# Altium schematic sheet Sensor Brushless Driver V1.01.SchDoc
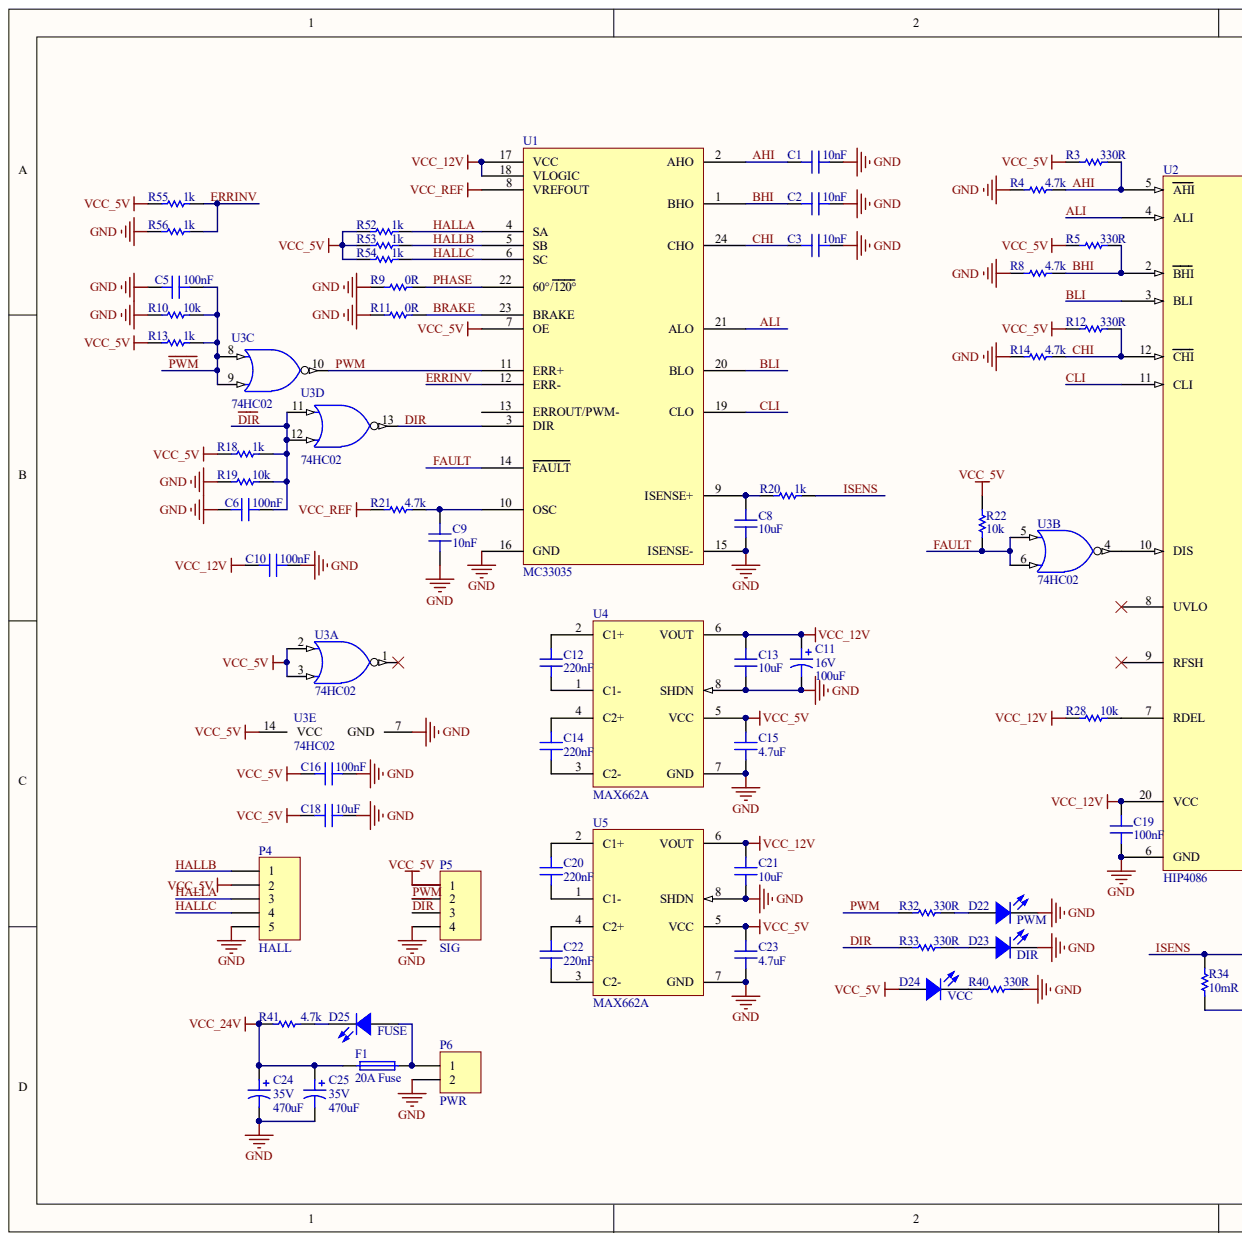
[diagram p0: Sensor Brushless Driver V1.01.SchDoc, left]
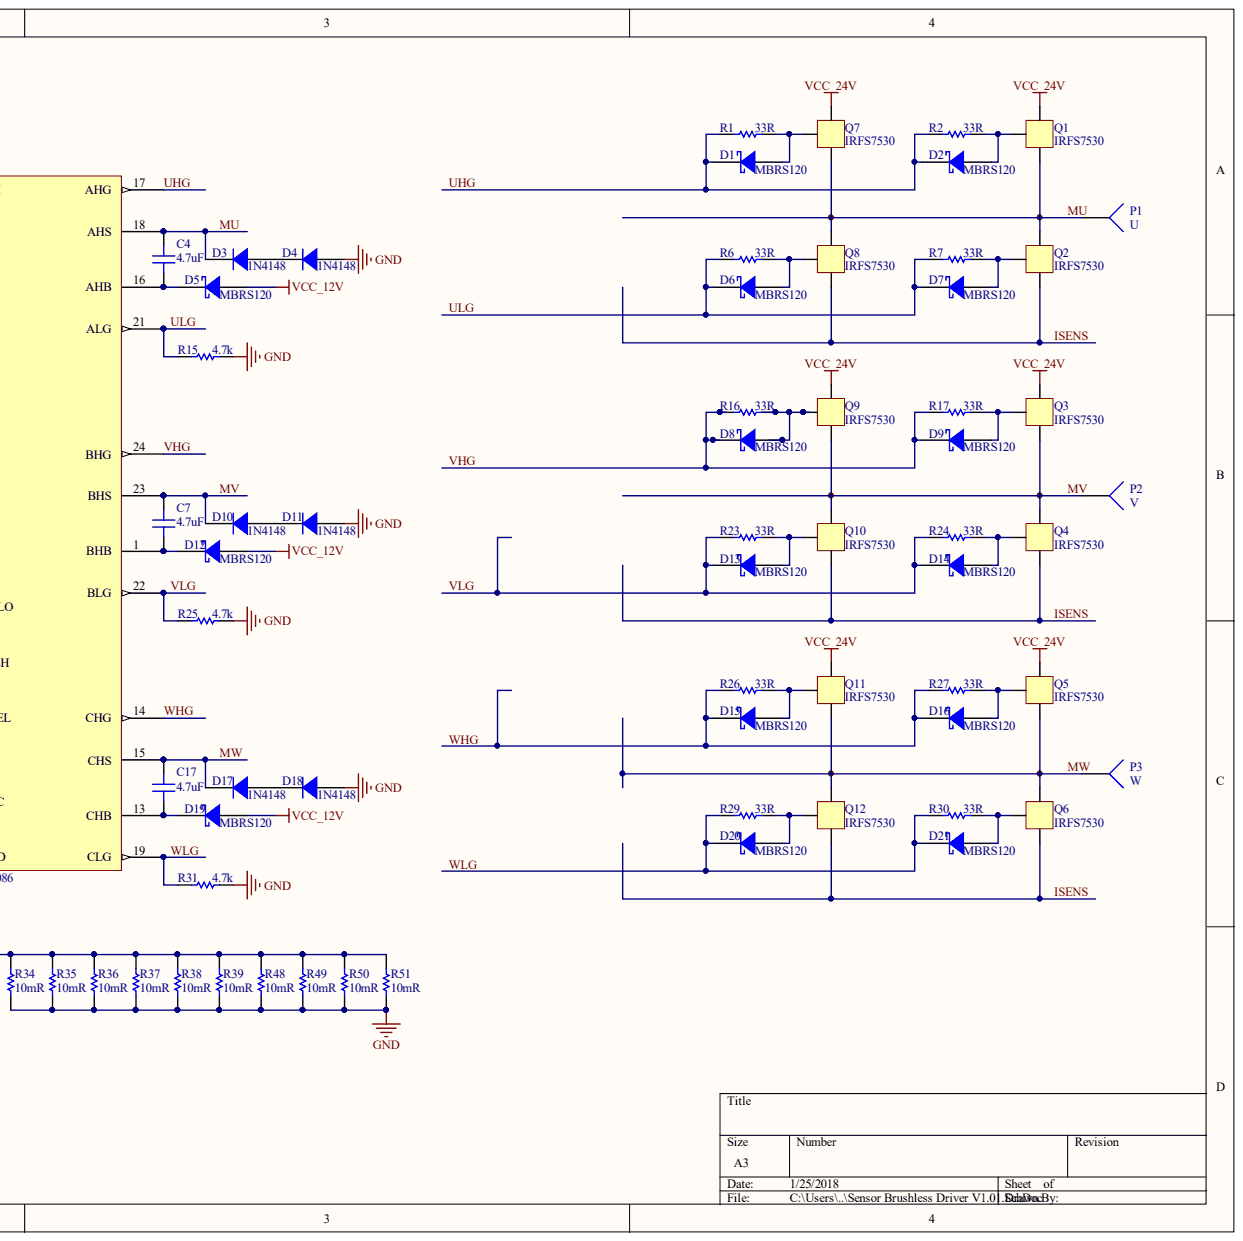
[diagram p1: Sensor Brushless Driver V1.01.SchDoc, right]

## Parts
C1  10nF  [CC2012-0805]
C2  10nF  [CC2012-0805]
C3  10nF  [CC2012-0805]
C4  4.7uF  [CC2012-0805]
C5  100nF  [CC2012-0805]
C6  100nF  [CC2012-0805]
C7  4.7uF  [CC2012-0805]
C8  10uF  [CC3216-1206]
C9  10nF  [CC2012-0805]
C10  100nF  [CC2012-0805]
C11  100uF  Voltage: 16V  [EIA7343]
C12  220nF  [CC2012-0805]
C13  10uF  [CC3216-1206]
C14  220nF  [CC2012-0805]
C15  4.7uF  [CC2012-0805]
C16  100nF  [CC2012-0805]
C17  4.7uF  [CC2012-0805]
C18  10uF  [CC3216-1206]
C19  100nF  [CC2012-0805]
C20  220nF  [CC2012-0805]
C21  10uF  [CC3216-1206]
C22  220nF  [CC2012-0805]
C23  4.7uF  [CC2012-0805]
C24  470uF  Voltage: 35V  [CAP10x12]
C25  470uF  Voltage: 35V  [CAP10x12]
D1  MBRS120  [SMA]
D2  MBRS120  [SMA]
D3  1N4148  [SOD-80]
D4  1N4148  [SOD-80]
D5  MBRS120  [SMA]
D6  MBRS120  [SMA]
D7  MBRS120  [SMA]
D8  MBRS120  [SMA]
D9  MBRS120  [SMA]
D10  1N4148  [SOD-80]
D11  1N4148  [SOD-80]
D12  MBRS120  [SMA]
D13  MBRS120  [SMA]
D14  MBRS120  [SMA]
D15  MBRS120  [SMA]
D16  MBRS120  [SMA]
D17  1N4148  [SOD-80]
D18  1N4148  [SOD-80]
D19  MBRS120  [SMA]
D20  MBRS120  [SMA]
D21  MBRS120  [SMA]
D22  PWM  [D0805]
D23  DIR  [D0805]
D24  VCC  [D0805]
D25  FUSE  [D0805]
F1  20A Fuse  [FUSE SOCKET]
P1  U  [2.5X4_WIRE_PAD]
P2  V  [2.5X4_WIRE_PAD]
P3  W  [2.5X4_WIRE_PAD]
P4  HALL  [LOCKEDHDR-5W]
P5  SIG  [LOCKEDHDR-4W]
P6  PWR  [xt60(m)]
Q1  IRFS7530  [D2k 7 pin]
Q2  IRFS7530  [D2k 7 pin]
Q3  IRFS7530  [D2k 7 pin]
Q4  IRFS7530  [D2k 7 pin]
Q5  IRFS7530  [D2k 7 pin]
Q6  IRFS7530  [D2k 7 pin]
Q7  IRFS7530  [D2k 7 pin]
Q8  IRFS7530  [D2k 7 pin]
Q9  IRFS7530  [D2k 7 pin]
Q10  IRFS7530  [D2k 7 pin]
Q11  IRFS7530  [D2k 7 pin]
Q12  IRFS7530  [D2k 7 pin]
R1  33R  [CR2012-0805]
R2  33R  [CR2012-0805]
R3  330R  [CR2012-0805]
R4  4.7k  [CR2012-0805]
R5  330R  [CR2012-0805]
R6  33R  [CR2012-0805]
R7  33R  [CR2012-0805]
R8  4.7k  [CR2012-0805]
R9  0R  [CR2012-0805]
R10  10k  [CR2012-0805]
R11  0R  [CR2012-0805]
R12  330R  [CR2012-0805]
R13  1k  [CR2012-0805]
R14  4.7k  [CR2012-0805]
R15  4.7k  [CR2012-0805]
R16  33R  [CR2012-0805]
R17  33R  [CR2012-0805]
R18  1k  [CR2012-0805]
R19  10k  [CR2012-0805]
R20  1k  [CR2012-0805]
R21  4.7k  [CR2012-0805]
R22  10k  [CR2012-0805]
R23  33R  [CR2012-0805]
R24  33R  [CR2012-0805]
R25  4.7k  [CR2012-0805]
R26  33R  [CR2012-0805]
R27  33R  [CR2012-0805]
R28  10k  [CR2012-0805]
R29  33R  [CR2012-0805]
R30  33R  [CR2012-0805]
R31  4.7k  [CR2012-0805]
R32  330R  [CR2012-0805]
R33  330R  [CR2012-0805]
R34  10mR  [CR6332-2512]
R35  10mR  [CR6332-2512]
R36  10mR  [CR6332-2512]
R37  10mR  [CR6332-2512]
R38  10mR  [CR6332-2512]
R39  10mR  [CR6332-2512]
R40  330R  [CR2012-0805]
R41  4.7k  [CR2012-0805]
R48  10mR  [CR6332-2512]
R49  10mR  [CR6332-2512]
R50  10mR  [CR6332-2512]
R51  10mR  [CR6332-2512]
R52  1k  [CR2012-0805]
R53  1k  [CR2012-0805]
R54  1k  [CR2012-0805]
R55  1k  [CR2012-0805]
R56  1k  [CR2012-0805]
U1  MC33035  [SOP-24]
U2  HIP4086  [SOP-24]
U3  74HC02  [SOP-14]  (parts A,B,C,D,E)
U4  MAX662A  [SOP-8]
U5  MAX662A  [SOP-8]

## Nets
GND: C1.1 C2.1 C3.1 C5.2 C6.2 C8.2 C9.2 C10.2 C11.2 C13.2 C15.2 C16.2 C18.2 C19.2 C21.2 C23.2 C24.2 C25.2 D4.1 D11.1 D18.1 D22.2 D23.2 P4.5 P5.4 P6.2 R4.2 R8.2 R9.2 R10.2 R11.2 R14.2 R15.1 R19.2 R25.1 R31.1 R34.1 R35.1 R36.1 R37.1 R38.1 R39.1 R40.1 R48.1 R49.1 R50.1 R51.1 R56.2 U1.15(ISENSE-) U1.16(GND) U2.6(GND) U3.7(GND) U4.7(GND) U4.8(SHDN) U5.7(GND) U5.8(SHDN)
VCC_5V: C15.1 C16.1 C18.1 C23.1 D24.1 P4.2 P5.1 R3.2 R5.2 R12.2 R13.2 R18.2 R22.2 R52.2 R53.2 R54.2 R55.2 U1.7(OE) U3.2 U3.3 U3.14(VCC) U4.5(VCC) U5.5(VCC)
VCC_12V: C10.1 C11.1 C13.1 C19.1 C21.1 D5.1 D12.1 D19.1 R28.2 U1.17(VCC) U1.18(VLOGIC) U2.20(VCC) U4.6(VOUT) U5.6(VOUT)
VCC_24V: C24.1 C25.1 F1.1 Q1.2 Q3.2 Q5.2 Q7.2 Q9.2 Q11.2 R41.1
VCC_REF: R21.1 U1.8(VREFOUT)
AHI: C1.2 R3.1 R4.1 U1.2(AHO) U2.5(AHI)
ALI: U1.21(ALO) U2.4(ALI)
BHI: C2.2 R5.1 R8.1 U1.1(BHO) U2.2(BHI)
BLI: U1.20(BLO) U2.3(BLI)
BRAKE: R11.1 U1.23(BRAKE)
CHI: C3.2 R12.1 R14.1 U1.24(CHO) U2.12(CHI)
CLI: U1.19(CLO) U2.11(CLI)
~{DIR}: C6.1 P5.3 R18.1 R19.1 U3.11 U3.12
DIR: R33.2 U1.3(DIR) U3.13
ERRINV: R55.1 R56.1 U1.12(ERR-)
FAULT: R22.1 U1.14(FAULT) U3.5 U3.6
HALLA: P4.3 R52.1 U1.4(SA)
HALLB: P4.1 R53.1 U1.5(SB)
HALLC: P4.4 R54.1 U1.6(SC)
ISENS: Q2.0 Q4.0 Q6.0 Q8.0 Q10.0 Q12.0 R20.1 R34.2 R35.2 R36.2 R37.2 R38.2 R39.2 R48.2 R49.2 R50.2 R51.2
MU: C4.2 D3.2 P1.1 Q1.0 Q2.2 Q7.0 Q8.2 U2.18(AHS)
MV: C7.2 D10.2 P2.1 Q3.0 Q4.2 Q9.0 Q10.2 U2.23(BHS)
MW: C17.2 D17.2 P3.1 Q5.0 Q6.2 Q11.0 Q12.2 U2.15(CHS)
~{PWM}: C5.1 P5.2 R10.1 R13.1 U3.8 U3.9
PHASE: R9.1 U1.22(60°/120°)
PWM: R32.2 U1.11(ERR+) U3.10
UHG: D1.2 D2.2 R1.1 R2.1 U2.17(AHG)
ULG: D6.2 D7.2 R6.1 R7.1 R15.2 U2.21(ALG)
VHG: D8.2 D9.2 R16.1 R17.1 U2.24(BHG)
VLG: D13.2 D14.2 R23.1 R24.1 R25.2 U2.22(BLG)
WHG: D15.2 D16.2 R26.1 R27.1 U2.14(CHG)
WLG: D20.2 D21.2 R29.1 R30.1 R31.2 U2.19(CLG)
NetC4_1: C4.1 D5.2 U2.16(AHB)
NetC7_1: C7.1 D12.2 U2.1(BHB)
NetC8_1: C8.1 R20.2 U1.9(ISENSE+)
NetC9_1: C9.1 R21.2 U1.10(OSC)
NetC12_1: C12.1 U4.1(C1-)
NetC12_2: C12.2 U4.2(C1+)
NetC14_1: C14.1 U4.3(C2-)
NetC14_2: C14.2 U4.4(C2+)
NetC17_1: C17.1 D19.2 U2.13(CHB)
NetC20_1: C20.1 U5.1(C1-)
NetC20_2: C20.2 U5.2(C1+)
NetC22_1: C22.1 U5.3(C2-)
NetC22_2: C22.2 U5.4(C2+)
NetD1_1: D1.1 Q7.1 R1.2
NetD2_1: D2.1 Q1.1 R2.2
NetD3_1: D3.1 D4.2
NetD6_1: D6.1 Q8.1 R6.2
NetD7_1: D7.1 Q2.1 R7.2
NetD8_1: D8.1 Q9.1 R16.2
NetD9_1: D9.1 Q3.1 R17.2
NetD10_1: D10.1 D11.2
NetD13_1: D13.1 Q10.1 R23.2
NetD14_1: D14.1 Q4.1 R24.2
NetD15_1: D15.1 Q11.1 R26.2
NetD16_1: D16.1 Q5.1 R27.2
NetD17_1: D17.1 D18.2
NetD20_1: D20.1 Q12.1 R29.2
NetD21_1: D21.1 Q6.1 R30.2
NetD22_1: D22.1 R32.1
NetD23_1: D23.1 R33.1
NetD24_2: D24.2 R40.2
NetD25_1: D25.1 F1.2 P6.1
NetD25_2: D25.2 R41.2
NetR28_1: R28.1 U2.7(RDEL)
NetU2_10: U2.10(DIS) U3.4

Not connected: U1 13(ERROUT/PWM-); U2 8(UVLO) 9(RFSH); U3 1

## Library data of the parts
C1-C10 C12-C23 = Cap Semi: Capacitor (Semiconductor SIM Model); Component Kind: Standard; LatestRevisionDate: 17-Jul-2002; LatestRevisionNote: Re-released for DXP Platform.; Library Name: Robocon_RCL.IntLib; PackageReference: C1206; Published: 8-Jun-2000; Signal Integrity: Cap Semi; Publisher: Altium Limited
C11 = Cap Pol SMD: Polarized Capacitor (Axial); Component Kind: Standard; LatestRevisionDate: 17-Jul-2002; LatestRevisionNote: Re-released for DXP Platform.; Library Name: Robocon_RCL.IntLib; Published: 8-Jun-2000; Publisher: Altium Limited
C24 C25 = Cap Pol DIP: Polarized Capacitor (Radial); Component Kind: Standard; LatestRevisionDate: 17-Jul-2002; LatestRevisionNote: Re-released for DXP Platform.; Library Name: Robocon_RCL.IntLib; Published: 8-Jun-2000; Publisher: Altium Limited
D1 D2 D5-D9 D12-D16 D19-D21 = D Schottky: Schottky Diode; Code - JEDEC: DO-214-AA; Component Kind: Standard; Library Name: Robocon_BJT.IntLib; Published: 8-Jun-2000; Revision: July-2002: Re-released for DXP Platform.; Publisher: Altium Limited
D3 D4 D10 D11 D17 D18 = D General: General Purpose Diode; Code - JEDEC: DO-204-AH; Revision 1: 11-Jul-2000: SIM Model Added.; Revision 2: 17-Jul-2002: Re-released for DXP Platform.; Component Kind: Standard; Datasheet: Latest Revision: 1997; Library Name: Robocon_BJT.IntLib; Package Reference: DO-35; Published: 8-Jun-2000; Publisher: Altium Limited
D22-D25 = LED: Typical RED GaAs LED; Component Kind: Standard; LatestRevisionDate: 08-Jul-2005; LatestRevisionNote: Mech Layer 1 removed.; Library Name: Robocon_BJT.IntLib; PackageReference: LED-1; Published: 8-Jun-2000; Publisher: Altium Limited
F1 = Fuse: Component Kind: Standard; Description: Fuse; Library Name: Robocon_RCL.IntLib; Published: 8-Jun-2000; Revision: July-2002: Re-released for DXP Platform.; Publisher: Altium Limited
P1-P3 = Socket: Component Kind: Standard; Description: Socket; LatestRevisionDate: 17-Jul-2002; LatestRevisionNote: Re-released for DXP Platform.; Library Name: Robocon_connectors.IntLib; Signal Integrity: Connector; Publisher: Altium Limited
P4 = Header 5: Header, 5-Pin; Component Kind: Standard; LatestRevisionDate: 17-Jul-2002; LatestRevisionNote: Re-released for DXP Platform.; Library Name: Robocon_connectors.IntLib; Signal Integrity: Connector; Publisher: Altium Limited
P5 = Header 4: Header, 4-Pin; Component Kind: Standard; Library Name: Robocon_connectors.IntLib; Revision: 17-Jul-2002: Re-released for DXP Platform.; Signal Integrity: Connector; Publisher: Altium Limited
P6 = Header 2: Header, 2-Pin; Component Kind: Standard; Library Name: Robocon_connectors.IntLib; Revision: 17-Jul-2002: Re-released for DXP Platform.; Signal Integrity: Connector; Publisher: Altium Limited
Q1-Q12 = D2k 7: Component Kind: Standard; Library Name: Schlib1.SchLib
R1-R41 R48-R56 = Res Semi: Semiconductor Resistor; Component Kind: Standard; LatestRevisionDate: 17-Jul-2002; LatestRevisionNote: Re-released for DXP Platform.; Library Name: Robocon_RCL.IntLib; Published: 8-Jun-2000; Signal Integrity: Res Semi; Publisher: Altium Limited
U1 = MC33035: 80V/2.5A Peak, High Frequency Full / Bridge FET Driver; Component Kind: Standard; Library Name: Robocon_IC.IntLib
U2 = HIP4086: 80V, 500mA, 3-Phase MOSFET Driver80V, 500mA, 3-Phase MOSFET Driver; Component Kind: Standard; Library Name: Robocon_IC.IntLib
U3 = 74HC02: Quadruple 2-Input Positive-NOR Gate; Package Information: Latest Revision: Jan-1998; Component Kind: Standard; Datasheet: Latest Revision: Mar-1988; Library Name: Robocon_IC.IntLib; Package Reference: D014; Published: 8-Jun-2000; Revision: 17-Jul-2002: Re-released for DXP Platform.; Publisher: Altium Limited
U4 U5 = MAX662A: 5V to 12V Charge Pump; Component Kind: Standard; Library Name: Robocon_IC.IntLib
